# Altium schematic sheet LCD.SchDoc
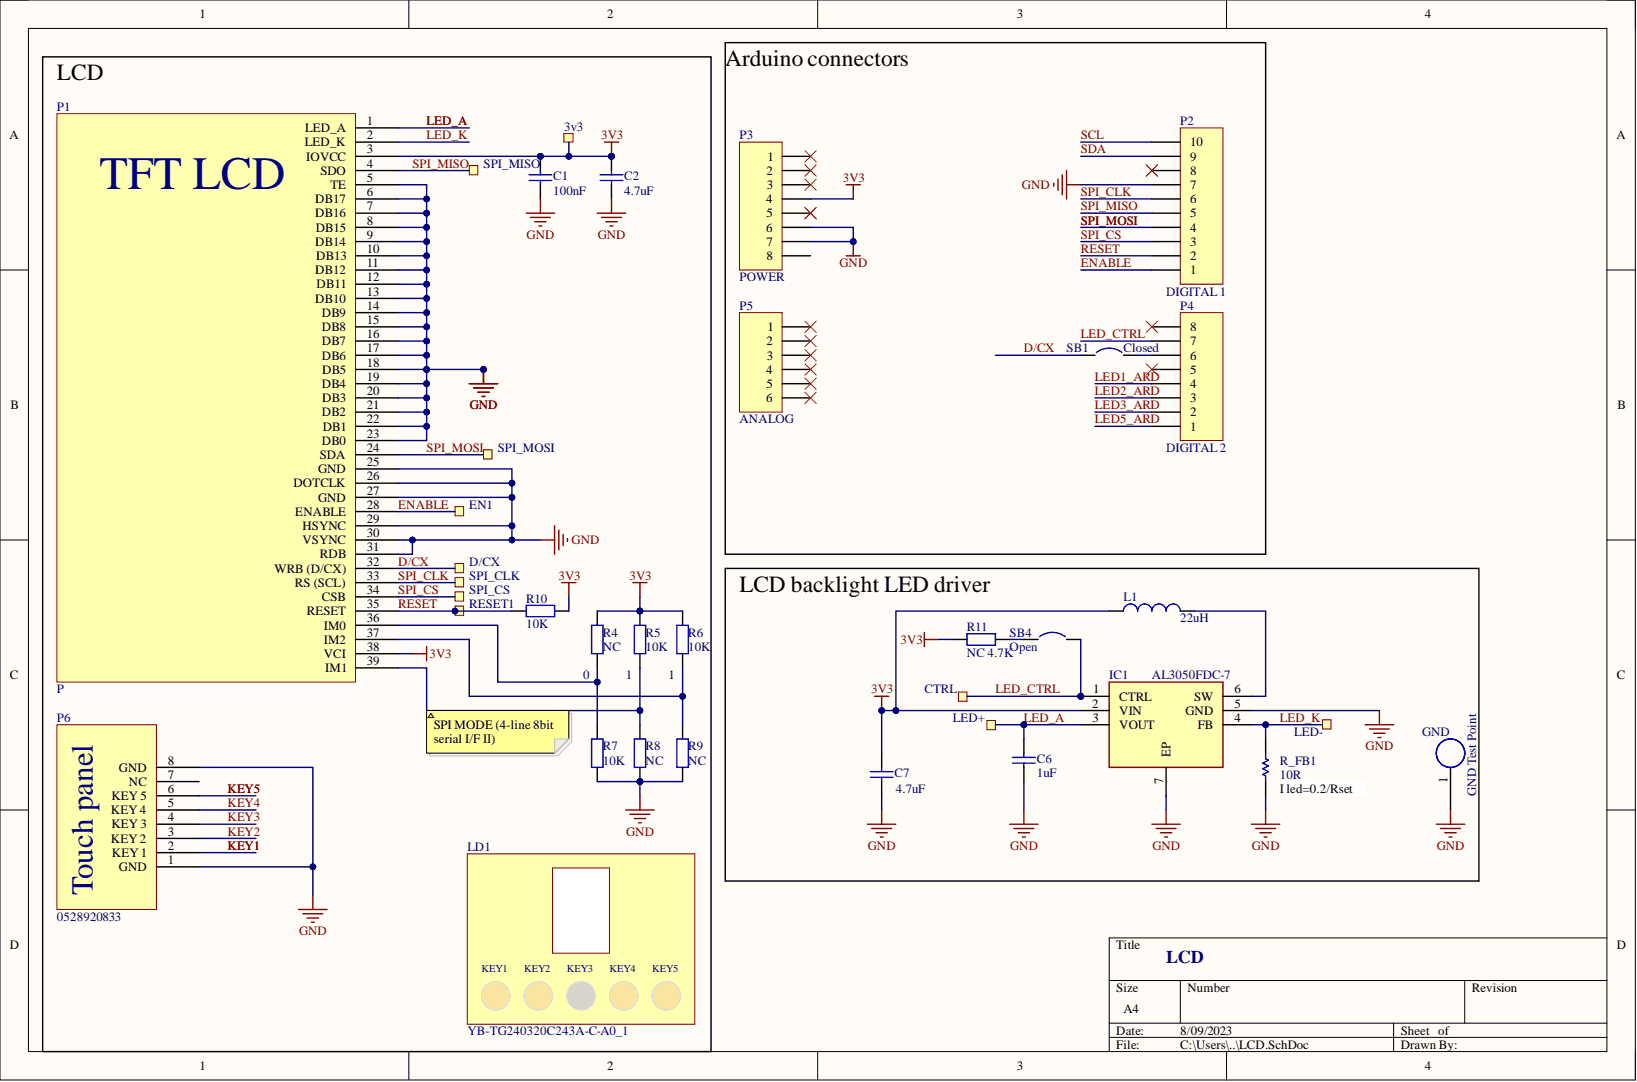
[diagram p0: LCD.SchDoc]

## Parts
3v3  Test point  [TP_SMD_1.0mm]  (value not drawn)  (no BOM)
C1  value 100nF  [c0402]  (hidden comment: Cap Semi)
C2  value 4.7uF  [c0402]  (hidden comment: Cap Semi)
C6  value 1uF  [C0402]  (hidden comment: Cap Semi)
C7  value 4.7uF  [C0402]  (hidden comment: Cap Semi)
CTRL  Test point  [TP_SMD_1.0mm]  (value not drawn)  (no BOM)
D/CX  Test point  [TP_SMD_1.0mm]  (value not drawn)  (no BOM)
EN1  Test point  [TP_SMD_1.0mm]  (value not drawn)  (no BOM)
GND  GND Test Point  [5019_keystone]
IC1  AL3050FDC-7  [SON65P200X200X61-7N]
L1  value 22uH  [L2016X12N]
LED+  Test point  [TP_SMD_1.0mm]  (value not drawn)  (no BOM)
LED-  Test point  [TP_SMD_1.0mm]  (value not drawn)  (no BOM)
P1  P  [FPC-39-0.3mm]
P2  DIGITAL 1  [Header  10way SMT 2.54mm 1row]
P3  POWER  [Header  8way SMT 2.54mm 1row]
P4  DIGITAL 2  [Header  8way SMT 2.54mm 1row]
P5  ANALOG  [Header  6way SMT 2.54mm 1row]
P6  0528920833  [CONN FFC BOTTOM 8POS 0.50MM]
R4  value NC  [R0402 - open]  (hidden comment: Res2)  (no BOM)
R5  value 10K  [R0402]  (hidden comment: Res2)
R6  value 10K  [R0402]  (hidden comment: Res2)
R7  value 10K  [R0402]  (hidden comment: Res2)
R8  value NC  [R0402 - open]  (hidden comment: Res2)  (no BOM)
R9  value NC  [R0402 - open]  (hidden comment: Res2)  (no BOM)
R10  value 10K  [R0402]  (hidden comment: Res2)
R11  NC 4.7K  [R0402 - open]  (no BOM)
R_FB1  value 10R  [R0402]  (hidden comment: Res1)
RESET1  Test point  [TP_SMD_1.0mm]  (value not drawn)  (no BOM)
SB1  Closed  [SB_0402]
SB4  Open  [SB_0402]  (no BOM)
SPI_CLK  Test point  [TP_SMD_1.0mm]  (value not drawn)  (no BOM)
SPI_CS  Test point  [TP_SMD_1.0mm]  (value not drawn)  (no BOM)
SPI_MISO  Test point  [TP_SMD_1.0mm]  (value not drawn)  (no BOM)
SPI_MOSI  Test point  [TP_SMD_1.0mm]  (value not drawn)  (no BOM)

## Nets
3V3: 3v3.1 C1.2 C2.2 C7.1 IC1.2(VIN) L1.1 P1.3(IOVCC) P1.38(VCI) P3.4 R4.1 R5.1 R6.1 R10.2 R11.1
GND: C1.1 C2.1 C6.2 C7.2 GND.1 IC1.5(GND) IC1.7(EP) P1.5(TE) P1.6(DB17) P1.7(DB16) P1.8(DB15) P1.9(DB14) P1.10(DB13) P1.11(DB12) P1.12(DB11) P1.13(DB10) P1.14(DB9) P1.15(DB8) P1.16(DB7) P1.17(DB6) P1.18(DB5) P1.19(DB4) P1.20(DB3) P1.21(DB2) P1.22(DB1) P1.23(DB0) P1.25(GND) P1.26(DOTCLK) P1.27(GND) P1.29(HSYNC) P1.30(VSYNC) P1.31(RDB) P2.7 P3.6 P3.7 P6.1(GND) P6.8(GND) R7.2 R8.2 R9.1 R_FB1.1
D/CX: D/CX.1 P1.32(WRB_(D/CX)) SB1.2
ENABLE: EN1.1 P1.28(ENABLE) P2.1
KEY1: P6.2(KEY_1)
KEY2: P6.3(KEY_2)
KEY3: P6.4(KEY_3)
KEY4: P6.5(KEY_4)
KEY5: P6.6(KEY_5)
LED1_ARD: P4.4
LED2_ARD: P4.3
LED3_ARD: P4.2
LED5_ARD: P4.1
LED_A: C6.1 IC1.3(VOUT) LED+.1 P1.1(LED_A)
LED_CTRL: CTRL.1 IC1.1(CTRL) P4.7 SB4.1
LED_K: IC1.4(FB) LED-.1 P1.2(LED_K) R_FB1.2
RESET: P1.35(RESET) P2.2 R10.1 RESET1.1
SCL: P2.10
SDA: P2.9
SPI_CLK: P1.33(RS_(SCL)) P2.6 SPI_CLK.1
SPI_CS: P1.34(CSB) P2.3 SPI_CS.1
SPI_MISO: P1.4(SDO) P2.5 SPI_MISO.1
SPI_MOSI: P1.24(SDA) P2.4 SPI_MOSI.1
NetIC1_6: IC1.6(SW) L1.2
NetP1_36: P1.36(IM0) R4.2 R7.1
NetP1_37: P1.37(IM2) R6.2 R9.2
NetP1_39: P1.39(IM1) R5.2 R8.1
NetP4_6: P4.6 SB1.1
NetR11_2: R11.2 SB4.2

Not connected: P2 8; P3 1 2 3 5 8; P4 5 8; P5 1 2 3 4 5 6; P6 7(NC)

## Library data of the parts
3v3 CTRL D/CX EN1 LED+ LED- RESET1 SPI_CLK SPI_CS SPI_MISO SPI_MOSI = TP_SMD_Ø1.0MM: 1.0mm circular SMD testpad; Database Table Name: Connectors$; Library Name: Nordic Passive DB.DbLib; Value: Test point
C1 = Cap Semi: Capacitor (Semiconductor SIM Model); Manufacturer: YAGEO; Manufacturer Part No.: CC0402KRX7R9BB104; Part Description: Ceramic Capacitor; Specification: X7R, 50V, 10%; Component Kind: Standard; Library Name: Miscellaneous Devices.IntLib; Link: https://www.rutronik24.com/search-result/qs:KKK23619/reset:0; Signal Integrity: Cap Semi; Simulation: CAP; Supplier: Rutronik; Supplier part number: KKK23619
C2 C7 = Cap Semi: Capacitor (Semiconductor SIM Model); Manufacturer: Samsung; Manufacturer Part No.: CL05A475KP5NRNC; Part Description: Ceramic Capacitor; Specification: X5R, 10V, 10%; Component Kind: Standard; Library Name: Miscellaneous Devices.IntLib; Link: https://www.rutronik24.com/product/samsung+em/cl05a475kp5nrnc/32126.html; Signal Integrity: Cap Semi; Simulation: CAP; Supplier: Rutronik; Supplier part number: KKS2214
C6 = Cap Semi: Capacitor (Semiconductor SIM Model); Manufacturer: muRata; Manufacturer Part No.: GRM155R61E105KA12D; Part Description: Ceramic Capacitor; Specification: X5R, 25V, 10%; Component Kind: Standard; Library Name: Miscellaneous Devices.IntLib; Link: https://www.rutronik24.com/product/murata/grm155r61e105ka12d/4725132.html; Signal Integrity: Cap Semi; Simulation: CAP; Supplier: Rutronik; Supplier part number: KKS4987
GND = 5019: SMD Test Point; Manufacturer: Keystone Electrincs; Part Description: SMT Test Point; Specification: 3.81*2.03; Value: 5019; Component Kind: Standard; Library Name: test_point.SchLib; Link: https://www.rutronik24.com/product/keystone/pn5019/7028403.html; Manufacturer Part No.: 5019; Supplier: Rutronik; Supplier part number: BAT4950
IC1 = AL3050FDC-7: Integrated Circuit; Manufacturer: Diodes Inc.; Part Description: driver Step-up; Specification: 2.7-5.5 to 30V 40mA; Component Kind: Standard; Datasheet Link: https://www.diodes.com/search/?q=AL3050&t=keyword&action_results=Go; Library Name: SamacSys.SchLib; Link: https://www.rutronik24.com/search-result/qs:AL3050FDC-7/reset:0; Manufacturer Part No.: AL3050FDC-7; Supplier: Rutronik; Supplier part number: LEDDRV1525; Value: AL3050FDC-7
L1 = Inductor: Manufacturer: TDK EUROPE; Manufacturer Part No.: MLZ2012N220LT000; Specification: 0805  ,300mA; Component Kind: Standard; Description: Inductor; Library Name: Miscellaneous Devices.IntLib; Link: https://www.rutronik24.com/search-result/qs:MLZ2012N220LT000/reset:0; Part Description: Inductor; Signal Integrity: Inductor; Simulation: INDUCTOR; Supplier: Rutronik; Supplier part number: MLZ2012N220LT000
P1 = P: FPC CONNECTOR OF LCD MODULE; Manufacturer: Molex; Manufacturer Part No.: 5035663902; Part Description: FPC Connector, 0.30mm Pitch, Right-Angle, Bottom Contact, 0.95mm Height, 39 Circuits, Gold over Nickel Plating; Specification: SMT, 0,3mm pitch 39 circuits; Value: 5035663902; Component Kind: Standard; Library Name: Schlib1.SchLib; Link: https://www.rutronik24.com/search-result/qs:5035663902/reset:0; Supplier: Rutronik; Supplier part number: CONN4076
P2 = Header 10: Manufacturer: Amphenol ICC; Manufacturer Part No.: 10129380-910002ALF; Part Description: SMT Header; Specification: 10 pos. 2,54mm; Value: 10129380-910002ALF; Component Kind: Standard; Library Name: Miscellaneous Connectors.IntLib; Link: https://www.rutronik24.com/search-result/qs:10129380-910002ALF/reset:0; Signal Integrity: Connector; Supplier: Rutronik; Supplier part number: CONN4527
P3 P4 = Header 8: Manufacturer: Amphenol ICC; Manufacturer Part No.: 10129380-908002ALF; Part Description: SMT Header; Specification: 8 pos. 2,54mm; Value: 10129380-908002ALF; Component Kind: Standard; Library Name: Miscellaneous Connectors.IntLib; Link: https://www.rutronik24.com/search-result/qs:10129380-908002ALF/reset:0; Signal Integrity: Connector; Supplier: Rutronik; Supplier part number: 10129380908002ALF
P5 = Header 6: Manufacturer: Amphenol ICC; Manufacturer Part No.: 10129380-906002BLF; Part Description: SMT Header; Specification: 6 pos. 2,54mm; Value: 10129380-906002BLF; Component Kind: Standard; Library Name: Miscellaneous Connectors.IntLib; Link: https://www.rutronik24.com/search-result/qs:10129380-906002BLF/reset:0; Signal Integrity: Connector; Supplier: Rutronik; Supplier part number: 10129380906002BLF
P6 = 0528920833: CONN FFC BOTTOM 8POS 0.50MM R/A; Manufacturer: Molex; Part Description: FPC Easy-On Connectors; Specification: SMT, 0,5mm 8 circuits bottom right angle; Component Kind: Standard; Library Name: Schlib1.SchLib; Link: https://www.digikey.de/product-detail/de/molex/0528920833/WM11082DKR-ND/5171208; Manufacturer Part No.: 0528920833; Supplier: Digikey; Supplier part number: WM11082DKR-ND
R4 R6 R8 R10 = Res2: Manufacturer: ASJ; Manufacturer Part No.: CR10-103-JK; Part Description: Thick Film Resistor; Specification: 5% 0,063W; Library Name: Miscellaneous Devices.IntLib; Link: https://www.rutronik24.com/product/asj/cr10-103-jk/54295.html; Signal Integrity: Res; Simulation: RESISTOR; Supplier: Rutronik; Supplier part number: WRC40976
  R6 R10: Component Kind: Standard
R5 R7 R9 = Res2: Part Description: Not mounted; Library Name: Miscellaneous Devices.IntLib; Signal Integrity: Res; Simulation: RESISTOR
  R5: Component Kind: Standard
  R7: Component Kind: Standard; Supplier part number: WRC40976
  R9: Supplier part number: WRC40976
R11 = Res2: Manufacturer: ASJ; Manufacturer Part No.: CR10-472-JK; Part Description: Thick Film Resistor; Specification: 5% 0,063W; Library Name: Miscellaneous Devices.IntLib; Link: https://www.rutronik24.com/product/asj/cr10-472-jk/54393.html; Signal Integrity: Res; Simulation: RESISTOR; Supplier: Rutronik; Supplier part number: WRC41427; Value: NC 4.7K
R_FB1 = Res1: Resistor; Manufacturer: ASJ; Manufacturer Part No.: CR10-10R0-FK; Part Description: Thick Film Resistor; Specification: 1% 0,063W; Component Kind: Standard; Library Name: Miscellaneous Devices.IntLib; Link: https://www.rutronik24.com/product/asj/cr10-10r0-fk/52610.html; Signal Integrity: Res; Simulation: RESISTOR; Supplier: Rutronik; Supplier part number: WRC36380
SB1 SB4 = Circuit Breaker_close: Circuit Breaker; Manufacturer: Yageo; Manufacturer Part No.: AC0402FR-070RL; Part Description: Thick Film Resistor; Specification: 5% 0,063W; Value: 0R; Link: https://www.rutronik24.com/product/yageo/ac0402fr-070rl/1082692.html; Revision: July-2002: Re-released for DXP Platform.; Supplier: Rutronik; Supplier part number: WRC52534; Library Name: RutAppKit-LedDriverSensor.SCHLIB
  SB1: Component Kind: Standard
